# Altium schematic sheet Main.SchDoc
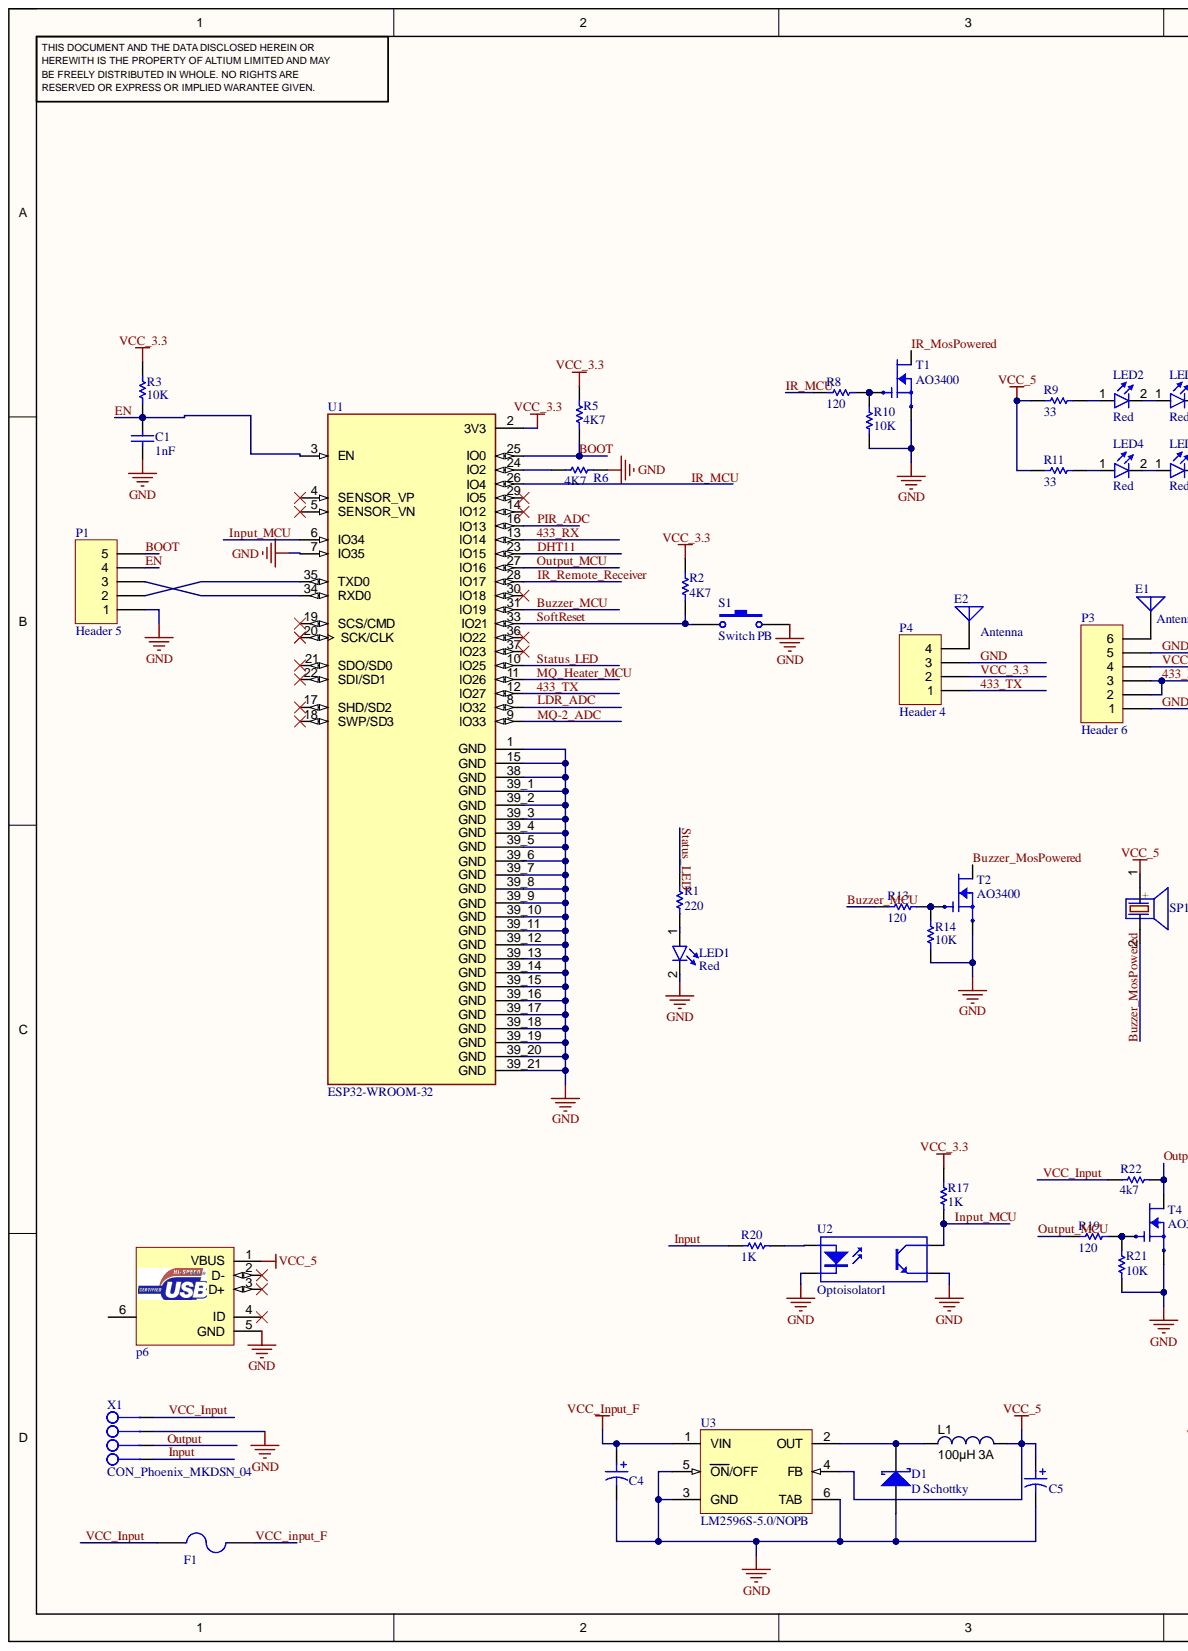
[diagram p0: Main.SchDoc, left]
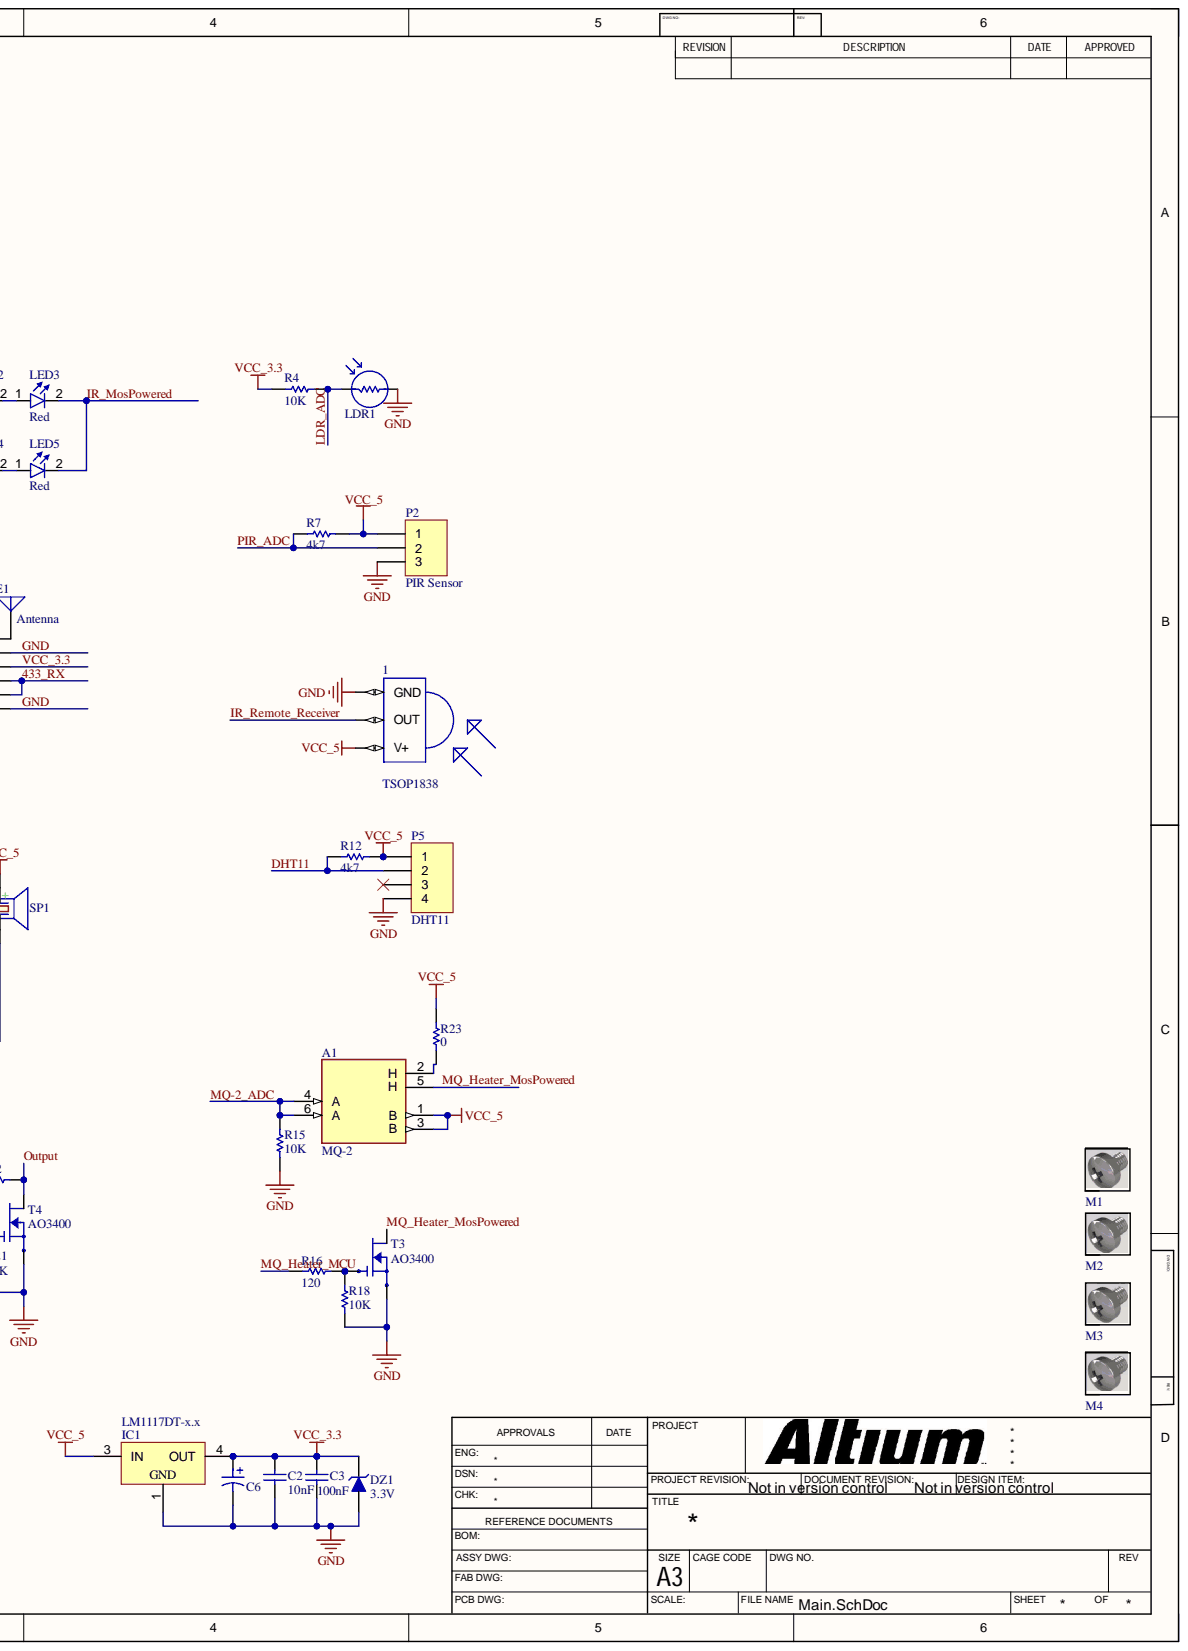
[diagram p1: Main.SchDoc, right]

## Parts
1  TSOP1838  [TSOP18XX]
A1  MQ-2  [XDCR_MQ-7]
C1  1nF  [CAPC4817X12N]
C2  10nF  [CAPC3413X12N]
C3  100nF  [CAPC3413X12N]
C4  220uF16V  [Cap electrolitic radial 6x7-2.5]  (value not drawn)
C5  (no value)  [Cap electrolitic radial 6x7-2.5]
C6  2200uf  [Cap electrolitic radial 6x7-2.5]  (value not drawn)
D1  D Schottky  [SMB]
DZ1  3.3V  [SOD80, LL-34]
E1  Antenna  [PIN1]
E2  Antenna  [PIN1]
F1  F=1.0 A  [1206 FUSE]  (value not drawn)
IC1  LM1117DT-x.x  [TD03B_N]
L1  100µH 3A  [Inductor 10x12 (200 mils)]
LDR1  PhotoCell  [PhotoCell]  (value not drawn)
LED1  Red  [LED-5mm]
LED2  Red  [LED-5mm-IR]
LED3  Red  [LED-5mm-IR]
LED4  Red  [LED-5mm-IR]
LED5  Red  [LED-5mm-IR]
M1  Screw  [Board Screw_No Silk]  (value not drawn)
M2  Screw  [Board Screw_No Silk]  (value not drawn)
M3  Screw  [Board Screw_No Silk]  (value not drawn)
M4  Screw  [Board Screw_No Silk]  (value not drawn)
P1  Header 5  [HDR1X5]
P2  PIR Sensor  [HDR1X3]  (value not drawn)
P3  Header 6  [HDR1X6]
P4  Header 4  [HDR1X4]
P5  DHT11  [HDR1X4]
p6  USB Connector  [USB_AB_BM_SHS]  (value not drawn)
R1  220  [RESC3413X04N]
R2  4K7  [RESC3413X04N]
R3  10K  [RESC3413X04N]
R4  10K  [RESC3413X04N]
R5  4K7  [RESC3413X04N]
R6  4K7  [RESC3413X04N]
R7  4k7  [RESC3413X04N]
R8  120  [RESC3413X04N]
R9  33  [RESC4817X04N]
R10  10K  [RESC3413X04N]
R11  33  [RESC4817X04N]
R12  4k7  [RESC3413X04N]
R13  120  [RESC3413X04N]
R14  10K  [RESC3413X04N]
R15  10K  [RESC3413X04N]
R16  120  [RESC3413X04N]
R17  1K  [RESC3413X04N]
R18  10K  [RESC3413X04N]
R19  120  [RESC3413X04N]
R20  1K  [RESC3413X04N]
R21  10K  [RESC3413X04N]
R22  4k7  [RESC3413X04N]
R23  0  [RESC4817X04N]
S1  Switch PB  [Switch - Push to On]
SP1  (no value)  [BUZZER 7.62mm DC]
T1  AO3400  [SOT23]
T2  AO3400  [SOT23]
T3  AO3400  [SOT23]
T4  AO3400  [SOT23]
U1  ESP32-WROOM-32  [MODULE_ESP32-WROOM-32]
U2  Optoisolator1  [DIP-4]
U3  LM2596S-5.0/NOPB  [TS5B_N]
X1  CON_Phoenix_MKDSN_04  [CON_MKDSN1,5/4-5,08]  (value not drawn)

## Nets
VCC_5: 1.VCC A1.1(B) A1.3(B) C5.1 IC1.3 L1.2 P2.1 P5.1 p6.1(VBUS) R7.1 R9.2 R11.2 R12.1 R23.1 SP1.1 U3.4(FB)
433_RX: P3.2 P3.3 U1.13(IO14)
433_TX: P4.1 U1.12(IO27)
BOOT: P1.5 R5.1 U1.25(IO0)
Buzzer_MCU: R13.1 U1.31(IO19)
Buzzer_MosPowered: SP1.2 T2.3
DHT11: P5.2 R12.2 U1.23(IO15)
EN: C1.2 P1.4 R3.1 U1.3(EN)
GND: 1.GND C1.1 C2.1 C3.1 C4.2 C5.2 C6.2 D1.1 DZ1.1 IC1.1 LDR1.2 LED1.2 P1.1 P2.3 P3.1 P3.5 P4.3 P5.4 p6.5(GND) R6.1 R10.1 R14.1 R15.2 R18.1 R21.1 S1.2 T1.2 T2.2 T3.2 T4.2 U1.1(GND) U1.7(IO35) U1.15(GND) U1.38(GND) U1.39_1(GND) U1.39_2(GND) U1.39_3(GND) U1.39_4(GND) U1.39_5(GND) U1.39_6(GND) U1.39_7(GND) U1.39_8(GND) U1.39_9(GND) U1.39_10(GND) U1.39_11(GND) U1.39_12(GND) U1.39_13(GND) U1.39_14(GND) U1.39_15(GND) U1.39_16(GND) U1.39_17(GND) U1.39_18(GND) U1.39_19(GND) U1.39_20(GND) U1.39_21(GND) U2.2 U2.3 U3.3(GND) U3.5(ON/OFF) U3.6(TAB) X1.3
Input: R20.2 X1.1
Input_MCU: R17.1 U1.6(IO34) U2.4
IR_MCU: R8.1 U1.26(IO4)
IR_MosPowered: LED3.2 LED5.2 T1.3
IR_Remote_Receiver: 1.OUT U1.28(IO17)
LDR_ADC: LDR1.1 R4.1 U1.8(IO32)
MQ-2_ADC: A1.4(A) A1.6(A) R15.1 U1.9(IO33)
MQ_Heater_MCU: R16.1 U1.11(IO26)
MQ_Heater_MosPowered: A1.5(H) T3.3
Output: R22.2 T4.3 X1.2
Output_MCU: R19.1 U1.27(IO16)
PIR_ADC: P2.2 R7.2 U1.16(IO13)
SoftReset: R2.1 S1.1 U1.33(IO21)
Status_LED: R1.2 U1.10(IO25)
VCC_3.3: C2.2 C3.2 C6.1 DZ1.2 IC1.4 P3.4 P4.2 R2.2 R3.2 R4.2 R5.2 R17.2 U1.2(3V3)
VCC_Input: F1.1 R22.1 X1.4
VCC_input_F: C4.1 F1.2 U3.1(VIN)
NetA1_2: A1.2(H) R23.2
NetD1_2: D1.2 L1.1 U3.2(OUT)
NetE1_1: E1.1 P3.6
NetE2_1: E2.1 P4.4
NetLED1_1: LED1.1 R1.1
NetLED2_1: LED2.1 R9.1
NetLED2_2: LED2.2 LED3.1
NetLED4_1: LED4.1 R11.1
NetLED4_2: LED4.2 LED5.1
NetP1_2: P1.2 p6.2(D-) p6.3(D+) p6.4(ID) p6.6 U1.35(TXD0)
NetP1_3: P1.3 U1.34(RXD0)
NetR6_2: R6.2 U1.24(IO2)
NetR8_2: R8.2 R10.2 T1.1
NetR13_2: R13.2 R14.2 T2.1
NetR16_2: R16.2 R18.2 T3.1
NetR19_2: R19.2 R21.2 T4.1
NetR20_1: R20.1 U2.1

Not connected: M1 S; M2 S; M3 S; M4 S; P5 3; U1 4(SENSOR_VP) 5(SENSOR_VN) 14(IO12) 17(SHD/SD2) 18(SWP/SD3) 19(SCS/CMD) 20(SCK/CLK) 21(SDO/SD0) 22(SDI/SD1) 29(IO5) 30(IO18) 36(IO22) 37(IO23)

## Library data of the parts
1 = TSOP1838: IR Remote Receiver 38KHz 35m 3-Pin; MF: Vishay; Availability: Unavailable; Component Kind: Standard; Library Name: TSOP1838.IntLib; MP: TSOP1838; Package: None; Price: None; SnapEDA_Link: https://www.snapeda.com/parts/TSOP1838/Vishay/view-part/561684/?ref=snap
A1 = MQ-7: Gas Sensor, High sensitivity to carbon monoxide; MF: Hanwei Electronics Co., Ltd; Availability: Unavailable; Check_prices: https://www.snapeda.com/parts/MQ-7/Hanwei%20Electronics%20Co.,%20Ltd/view-part/5661821/?ref=eda; Component Kind: Standard; Library Name: MQ-7.IntLib; MP: MQ-7; Package: Package; Price: None; SnapEDA_Link: https://www.snapeda.com/parts/MQ-7/Hanwei%20Electronics%20Co.,%20Ltd/view-part/5661821/?ref=snap
C1 = CAP SMD 1206: CAP 1206; Designer: Abbas Basereh; Component Kind: Standard; Data: 16-12-2016; Desc.: CAP SMD 1206; Library Name: General 1.IntLib
C2 C3 = CAP SMD 0805: CAP 0805; Designer: Abbas Basereh; Component Kind: Standard; Data: 16-12-2016; Desc.: CAP SMD 0805; Library Name: General 1.IntLib
C4 = Cap ELECTROLITIC TOTAL SIZE: Cap electrolitic radial; Designer: Abbas Basereh; Component Kind: Standard; Data: 16-12-2016; Library Name: General 1.IntLib; VALUE: 220uF16V
C5 C6 = Cap ELECTROLITIC TOTAL SIZE: Cap electrolitic radial; Designer: Abbas Basereh; VALUE: 2200uF6.3V; Component Kind: Standard; Data: 16-12-2016; Library Name: General 1.IntLib
D1 = D Schottky: Schottky Diode; PartNumber: 1N5822; Code_JEDEC: DO-214-AA; Component Kind: Standard; LatestRevisionDate: 17-Jul-2002; LatestRevisionNote: Re-released for DXP Platform.; Library Name: Miscellaneous Devices.IntLib; PackageDescription: DO-214-AA/SMB; 2 C-Bend Leads; Body 5.3 x 3.6 mm, inc. leads (LxW); PackageReference: SMB; Published: 8-Jun-2000; Signal Integrity: D Schottky; Simulation: SKYDIODE; Publisher: Altium Limited
DZ1 = ZENER GENERAL DIODE: DIODE ZENER GENERAL; Component Kind: Standard; ComponentLink1Description: Manufacturer Link; ComponentLink2Description: Datasheet; Library Name: General 1.IntLib; PackageDescription: DIODE GEN ZENER; PackageReference: DO 35; PackageVersion: 2017-1-12; Published: 2017-1-12; VALUE: 3.3V; Publisher: Abbas Basereh
E1 E2 = Antenna: Generic Antenna; Component Kind: Standard; LatestRevisionDate: 17-Jul-2002; LatestRevisionNote: Re-released for DXP Platform.; Library Name: Miscellaneous Devices.IntLib; PackageReference: PIN1; Published: 24-Mar-1999; Publisher: Altium Limited
F1 = Fuse SMD1206: SMD Fuses 1206; Designer: Abbas Basereh; Component Kind: Standard; Data: 16-12-2016; Desc.: SMD Fuses 1206; Library Name: General 1.IntLib
IC1 = LM1117DT-3.3: x.x Volt, 800mA Low-Dropout Linear Regulator, 3-pin TO-252; Manufacturer: National Semiconductor; CompType: LDO IC; PackageTopMarking: NSUZXYTT*LM1117*DT-X.X; PowerWise: N; Code_JEDEC: TO-252-AA; Component Kind: Standard; ComponentLink1Description: LM1117 Datasheet; ComponentLink1URL: http://www.national.com/ds/LM/LM1117.pdf; ComponentLink2Description: LM1117 Web Page; ComponentLink2URL: http://www.national.com/pf/LM/LM1117.html; ComponentLink3Description: TD03B Package Page; ComponentLink3URL: http://www.national.com/packaging/folders/td03b.html; DatasheetVersion: Apr-2006; Library Name: General 2.IntLib; PackageDescription: TO252, Molded, Jedec, 6.8 x 6.10 x 2.30mm Body, 3 Lead, 2.28mm Pitch, Surface Mount; PackageReference: TD03B; PackageVersion: revD, Feb-2009; PartNumber: LM1117DT-3.3; PinCount: 3; RoHS: N; Supplier 1: Farnell; Supplier 2: Farnell
L1 = POWER INDUCTOR 10x12 (200 mils): SCDType: source; Tolerance: ±20%; Type: Ferrite Core; Component Kind: Standard; Description: POWER INDUCTOR 10x12 (200 mils); Library Name: General 1.IntLib; Operating Temperature: -40°C ~ 125°C; Package / Case: POWER INDUCTOR 10x12 (200 mils); Supplier 1: Digi-Key; Value: 100µH 3A; PUBLISHER: ABBAS BASEREH  2017-3-1
LDR1 = LDR: Photo Cell- Variable Resistor With Light; Company: IPA CO.; Designer: Saeid Jorablo; Component Kind: Standard; Last Update Time: 2008/07/17; Library Name: General 2.IntLib
LED1-LED5 = LED: Designer: Saeid Jorablo; Organazation: ControTec; Adding Date: 1387/12/22; Color: Red; Component Kind: Standard; Description: LED; Library Name: General 2.IntLib
M1-M4 = Board Screw: Board Screw With Spacer; Component Kind: Standard; Library Name: General 2.IntLib
P1 = Header 5: Header, 5-Pin; Component Kind: Standard; LatestRevisionDate: 17-Jul-2002; LatestRevisionNote: Re-released for DXP Platform.; Library Name: Miscellaneous Connectors.IntLib; Signal Integrity: Connector; Publisher: Altium Limited
P2 = Header 3: Header, 3-Pin; Component Kind: Standard; LatestRevisionDate: 17-Jul-2002; LatestRevisionNote: Re-released for DXP Platform.; Library Name: Miscellaneous Connectors.IntLib; Signal Integrity: Connector; Publisher: Altium Limited
P3 = Header 6: Header, 6-Pin; Component Kind: Standard; LatestRevisionDate: 17-Jul-2002; LatestRevisionNote: Re-released for DXP Platform.; Library Name: Miscellaneous Connectors.IntLib; Signal Integrity: Connector; Publisher: Altium Limited
P4 P5 = Header 4: Header, 4-Pin; Component Kind: Standard; LatestRevisionDate: 17-Jul-2002; LatestRevisionNote: Re-released for DXP Platform.; Library Name: Miscellaneous Connectors.IntLib; Signal Integrity: Connector; Publisher: Altium Limited
p6 = USB AB MICRO CONNECTOR: USB MICRO-B Type Connector; Designer: ABBAS BASEREH; Max Current: 1600mA; Category: Connector; Component Kind: Standard; ComponentLink1Description: Datasheet; ComponentLink2Description: Reference; Library Name: General 1.IntLib; Mounting Technology: Surface Mount\Through-Hole; Pin Count: 5-7; Status: Unreleased; Update: 1395-11-17; Publisher: ABBAS BASEREH
R1-R8 R10 R12-R22 = RES 0805 0.125W: RES 0805; Desc.: RES SMD 0805; Designer: Abbas Basereh; Component Kind: Standard; Data: 16-12-2016; Library Name: General 1.IntLib
R9 R11 R23 = RES 1206 0.25W: RES 1206; Desc.: RES SMD 1206; Designer: Abbas Basereh; Component Kind: Standard; Data: 16-12-2016; Library Name: General 1.IntLib
S1 = Switch PB: Push Button Switch; Component Kind: Standard; Library Name: General 2.IntLib
SP1 = PIEZO BUZZER: PIEZO BUZZER ACTIVE; Comment_1: SPEAKER AND BEEP; Designer: Abbas Basereh; Component Kind: Standard; ComponentLink2Description: Reference; Design Date: 1395/11/14; Library Name: General 1.IntLib; Mounting Technology: THD; PackageDescription: THD; Pin Count: 2; Status: Unreleased; Publisher: Abbas Basereh
T1-T4 = AO3400: N-Channel 30V 5.8A _Ta_ 1.4W _Ta_ Surface Mount SOT-23-3; MF: Alpha &; Availability: Unavailable; Component Kind: Standard; Library Name: AO3400.IntLib; MP: AO3400; Package: SOT-23-3 Alpha &amp; Omega Semiconductor; Price: None; SnapEDA_Link: https://www.snapeda.com/parts/AO3400/Alpha%20%26%20Omega%20Semiconductor%20Inc./view-part/1321993/?ref=snap
U1 = ESP32-WROOM-32: Bluetooth, WiFi Transceiver Module 2.4GHz ~ 2.5GHz Surface Mount; MF: Espressif Systems; Component Kind: Standard; Digi-Key_Part_Number: 1904-1010-1-ND; Digi-Key_Purchase_URL: https://www.digikey.com/product-detail/en/espressif-systems/ESP32-WROOM-32/1904-1010-1-ND/8544305?utm_source=snapeda&utm_medium=aggregator&utm_campaign=symbol; Library Name: ESP32-WROOM-32.IntLib; MP: ESP32-WROOM-32; Package: Module Espressif Systems; SnapEDA_Link: https://www.snapeda.com/parts/ESP32-WROOM-32/Espressif%20Systems/view-part/2753322/?ref=snap
U2 = Optoisolator1: 4-Pin Phototransistor Optocoupler; Component Kind: Standard; DatasheetVersion: Nov-2003; LatestRevisionDate: 04-Mar-2005; LatestRevisionNote: Sch pins 3 & 4 Swapped.; Library Name: Miscellaneous Devices.IntLib; PackageReference: DIP-4; Published: 24-Mar-1999; Publisher: Altium Limited
U3 = LM2596S-5.0/NOPB: SIMPLE SWITCHER® Power Converter 150 KHz 3A Step-Down Voltage Regulator, 5-pin TO-263, Pb-Free; Manufacturer: National Semiconductor; High-Reliability: Y; PackageTopMarking: NSUZXYTTE#*LM2596S*-5.0 P+; PowerWise: N; Code_JEDEC: MO-169-AB; Component Kind: Standard; ComponentLink1Description: Manufacturer URL; ComponentLink1URL: http://www.national.com/; ComponentLink2Description: LM2596 Datasheet; ComponentLink2URL: http://www.national.com/ds/LM/LM2596.pdf; ComponentLink3Description: LM2596 Web Page; ComponentLink3URL: http://www.national.com/pf/LM/LM2596.html; ComponentLink4Description: TS5B Package Page; ComponentLink4URL: http://www.national.com/packaging/folders/ts5b.html; CompType: Switcher; DatasheetVersion: May-2002; Library Name: National Semiconductor Switcher.IntLib; PackageDescription: TO-263, Molded, .400 x .390 x .180in, 5 Lead, .067in Pitch, Surface Mount; PackageReference: TS5B; PackageVersion: revD, Apr-2005; PartNumber: LM2596S-5.0/NOPB; PinCount: 5; RoHS: Y
X1 = CON_Phoenix_MKDSN_04: Manufacturer: PHOENIX CONTACT; Company: SJ Libraries; Connector type: PCB Terminal Block; designer: Eng. Saeid Jorablo; Max Current: 13.5 A; Positions: 4; Revision 1: 2012/10/1 : Adding Model; Voltage: 400 V; Category: Connector; Component Kind: Standard; ComponentLink1Description: Datasheet; ComponentLink2Description: Reference; HelpURL: http://s3.picofile.com/file/7443264187/Coming_Soon_logo.gif; Library Name: Sockets And Connectors.IntLib; Mounting Technology: Through-Hole; Pin Count: 4; Status: Unreleased; Update: 2012/10/1; Publisher: SJ UnLimited
